# Altium schematic sheet STEREO_CAMERA_SHIELD_S01.SchDoc(STEREO_CAMERA_SHIELD_S01)
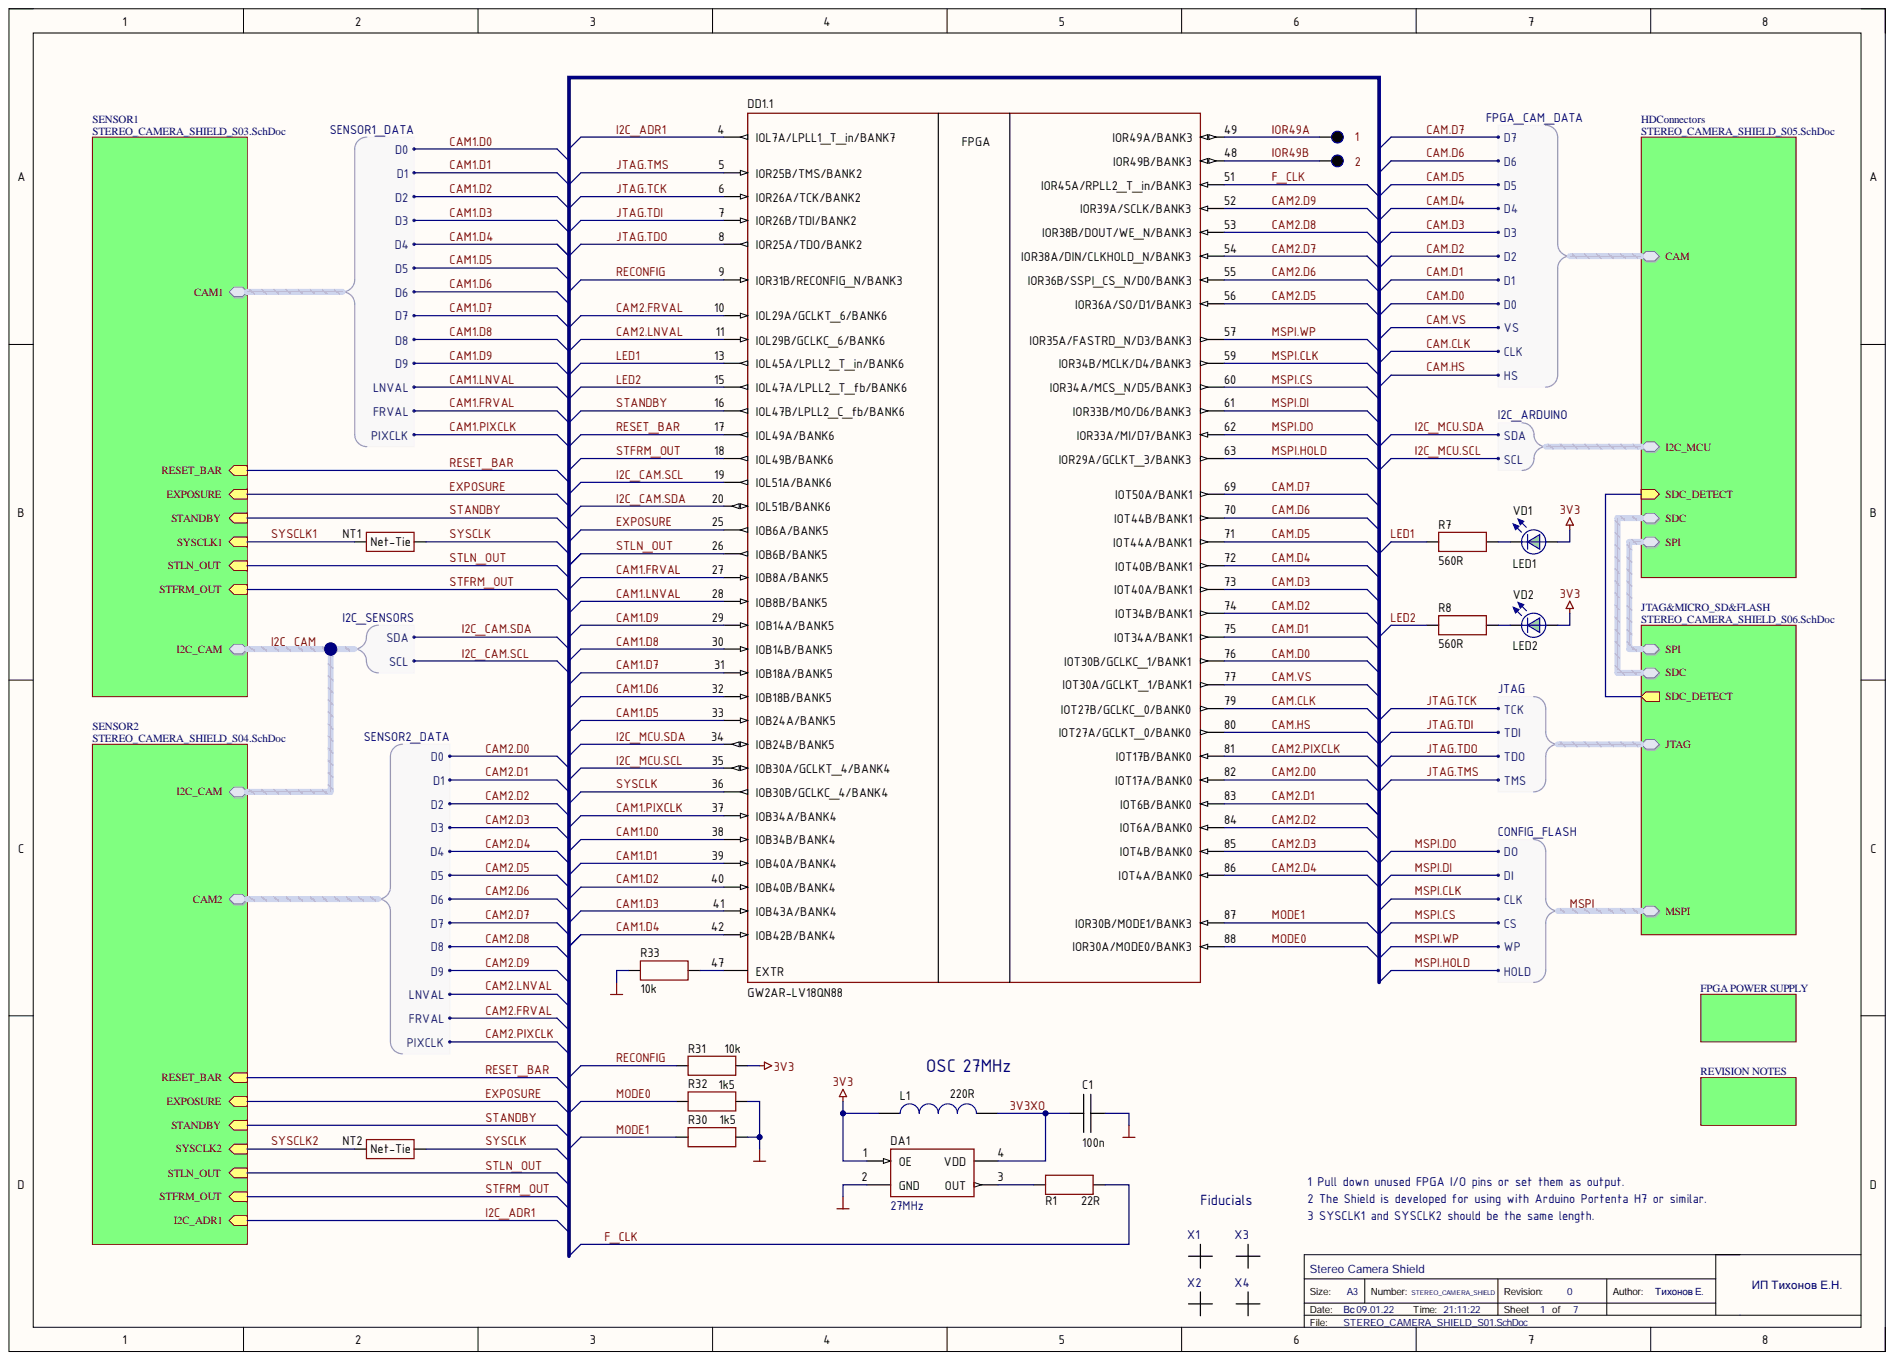
[diagram p0: STEREO_CAMERA_SHIELD_S01.SchDoc]

## Parts
1  test  [TEST_point_SMD_nosilk]  (value not drawn)  (no BOM)
2  test  [TEST_point_SMD_nosilk]  (value not drawn)  (no BOM)
C1  value 100n  [CAP: 0402]  (hidden comment: CER CAP 0402 100n 16V X7R ±5%)
DA1  Frequency: 27MHz  [OSC: 2520]  (hidden comment: XO (Standard), 27MHz, 50ppm, 2520 SMD)
DD1  ComponentType: FPGA  [88-QFN-TEP]  (hidden comment: FPGA, Arora GW2AR, , 1820,736 LUTs, 64M bits RAM, 1.0V, -40...+100°C, QFN88, 0.4mm)  (part .1)
L1  value 220R  [IND: 0603]  (hidden comment: FERRITE BEAD, 220R, , 0603, 500mA, -55...+125°C)
NT1  (no value)  [NetTie_2x0.15mm]
NT2  (no value)  [NetTie_2x0.15mm]
R1  value 22R  [RES: 0402]  (hidden comment: RES SMD 0402 22R ±5%)
R7  value 560R  [RES: 0402]  (hidden comment: RES SMD 0402 560R ±5%)
R8  value 560R  [RES: 0402]  (hidden comment: RES SMD 0402 560R ±5%)
R30  value 1k5  [RES: 0402]  (hidden comment: RES SMD 0402 1k5 ±5%)
R31  value 10k  [RES: 0402]  (hidden comment: RES SMD 0402 10k ±5%)
R32  value 1k5  [RES: 0402]  (hidden comment: RES SMD 0402 1k5 ±5%)
R33  value 10k  [RES: 0402]  (hidden comment: RES SMD 0402 10k ±5%)
VD1  ShowComment: LED1  [LED: 0603G]  (hidden comment: LED, 0603, GREEN)
VD2  ShowComment: LED2  [LED: 0603G]  (hidden comment: LED, 0603, GREEN)
X1  реперная метка для установщика  [REPER]  (value not drawn)  (no BOM)
X2  реперная метка для установщика  [REPER]  (value not drawn)  (no BOM)
X3  реперная метка для установщика  [REPER]  (value not drawn)  (no BOM)
X4  реперная метка для установщика  [REPER]  (value not drawn)  (no BOM)

## Nets
3V3: DA1.1(OE) L1.2 R31.2 VD1.1 VD2.1
GND: C1.1 DA1.2(GND) R30.1 R32.1 R33.1
3V3XO: C1.2 DA1.4(VDD) L1.1
CAM1.D0: DD1.38(IOB34B/BANK4)
CAM1.D1: DD1.39(IOB40A/BANK4)
CAM1.D2: DD1.40(IOB40B/BANK4)
CAM1.D3: DD1.41(IOB43A/BANK4)
CAM1.D4: DD1.42(IOB42B/BANK4)
CAM1.D5: DD1.33(IOB24A/BANK5)
CAM1.D6: DD1.32(IOB18B/BANK5)
CAM1.D7: DD1.31(IOB18A/BANK5)
CAM1.D8: DD1.30(IOB14B/BANK5)
CAM1.D9: DD1.29(IOB14A/BANK5)
CAM1.FRVAL: DD1.27(IOB8A/BANK5)
CAM1.LNVAL: DD1.28(IOB8B/BANK5)
CAM1.PIXCLK: DD1.37(IOB34A/BANK4)
CAM2.D0: DD1.82(IOT17A/BANK0)
CAM2.D1: DD1.83(IOT6B/BANK0)
CAM2.D2: DD1.84(IOT6A/BANK0)
CAM2.D3: DD1.85(IOT4B/BANK0)
CAM2.D4: DD1.86(IOT4A/BANK0)
CAM2.D5: DD1.56(IOR36A/SO/D1/BANK3)
CAM2.D6: DD1.55(IOR36B/SSPI_CS_N/D0/BANK3)
CAM2.D7: DD1.54(IOR38A/DIN/CLKHOLD_N/BANK3)
CAM2.D8: DD1.53(IOR38B/DOUT/WE_N/BANK3)
CAM2.D9: DD1.52(IOR39A/SCLK/BANK3)
CAM2.FRVAL: DD1.10(IOL29A/GCLKT_6/BANK6)
CAM2.LNVAL: DD1.11(IOL29B/GCLKC_6/BANK6)
CAM2.PIXCLK: DD1.81(IOT17B/BANK0)
CAM.CLK: DD1.79(IOT27B/GCLKC_0/BANK0)
CAM.D0: DD1.76(IOT30B/GCLKC_1/BANK1)
CAM.D1: DD1.75(IOT34A/BANK1)
CAM.D2: DD1.74(IOT34B/BANK1)
CAM.D3: DD1.73(IOT40A/BANK1)
CAM.D4: DD1.72(IOT40B/BANK1)
CAM.D5: DD1.71(IOT44A/BANK1)
CAM.D6: DD1.70(IOT44B/BANK1)
CAM.D7: DD1.69(IOT50A/BANK1)
CAM.HS: DD1.80(IOT27A/GCLKT_0/BANK0)
CAM.VS: DD1.77(IOT30A/GCLKT_1/BANK1)
EXPOSURE: DD1.25(IOB6A/BANK5)
F_CLK: DD1.51(IOR45A/RPLL2_T_in/BANK3) R1.2
I2C_ADR1: DD1.4(IOL7A/LPLL1_T_in/BANK7)
I2C_CAM.SCL: DD1.19(IOL51A/BANK6)
I2C_CAM.SDA: DD1.20(IOL51B/BANK6)
I2C_MCU.SCL: DD1.35(IOB30A/GCLKT_4/BANK4)
I2C_MCU.SDA: DD1.34(IOB24B/BANK5)
IOR49A: 1.1 DD1.49(IOR49A/BANK3)
IOR49B: 2.1 DD1.48(IOR49B/BANK3)
JTAG.TCK: DD1.6(IOR26A/TCK/BANK2)
JTAG.TDI: DD1.7(IOR26B/TDI/BANK2)
JTAG.TDO: DD1.8(IOR25A/TDO/BANK2)
JTAG.TMS: DD1.5(IOR25B/TMS/BANK2)
LED1: DD1.13(IOL45A/LPLL2_T_in/BANK6) R7.2
LED2: DD1.15(IOL47A/LPLL2_T_fb/BANK6) R8.2
MODE0: DD1.88(IOR30A/MODE0/BANK3) R32.2
MODE1: DD1.87(IOR30B/MODE1/BANK3) R30.2
MSPI.CLK: DD1.59(IOR34B/MCLK/D4/BANK3)
MSPI.CS: DD1.60(IOR34A/MCS_N/D5/BANK3)
MSPI.DI: DD1.61(IOR33B/MO/D6/BANK3)
MSPI.DO: DD1.62(IOR33A/MI/D7/BANK3)
MSPI.HOLD: DD1.63(IOR29A/GCLKT_3/BANK3)
MSPI.WP: DD1.57(IOR35A/FASTRD_N/D3/BANK3)
RECONFIG: DD1.9(IOR31B/RECONFIG_N/BANK3) R31.1
RESET_BAR: DD1.17(IOL49A/BANK6)
STANDBY: DD1.16(IOL47B/LPLL2_C_fb/BANK6)
STFRM_OUT: DD1.18(IOL49B/BANK6)
STLN_OUT: DD1.26(IOB6B/BANK5)
SYSCLK: DD1.36(IOB30B/GCLKC_4/BANK4) NT1.1 NT2.1
SYSCLK1: NT1.2
SYSCLK2: NT2.2
NetDA1_3: DA1.3(OUT) R1.1
NetDD1_47: DD1.47(EXTR) R33.2
NetR7_1: R7.1 VD1.2
NetR8_1: R8.1 VD2.2
SDC.CLK:
SDC.CMD:
SDC.D0:
SDC.D1:
SDC.D2:
SDC.D3:
SDC_DETECT:
SPI.CK:
SPI.CS:
SPI.MISO:
SPI.MOSI:

## Library data of the parts
1 2 = TEST point: Контактная площадка без компонента; Library Name: Connector.SchLib
C1 = Ceramic_0402: Manufacturer: Yageo; PartNumber: CC0402JRX7R7BB104; TypeCapacitor: CER CAP; Component Kind: Standard; Library Name: Capacitor.SchLib; Package: 0402; Temperature: -55...+125°C; Tolerance: ±5%; TypeDielectric: X7R; ValueManufacturerPartNumber: CER CAP 0402 100n 16V X7R ±5%; Voltage: 16V
DA1 = XO_2520: 27 MHz XO (Standard) CMOS Oscillator 3.3V Enable/Disable 4-SMD, No Lead; Manufacturer: Abracon LLC; PartNumber: ASDAIG-27.000MHZ-C-S-T; Component Kind: Standard; ComponentType: XO (Standard); HelpURL: https://www.digikey.com/en/products/detail/abracon-llc/ASDAIG-27-000MHZ-C-S-T/11476999; Library Name: Oscilator.SchLib; Package: 2520 SMD; Supply: 3.3V; Temperature: -40...+125°C; Tolerance: 50ppm; ValueManufacturerPartNumber: XO (Standard), 27MHz, 50ppm, 2520 SMD
DD1 = GW2AR-LV18QN88: FPGA Arora GW2AR; Manufacturer: Gowin; Component Kind: Standard; HelpURL: https://www.gowinsemi.com/en/product/detail/1/; Library Name: IC. FPGA.SchLib; LogicElements: 1820,736 LUTs; MemoryRAM: 64M bits RAM; Package: QFN88, 0.4mm; PartNumber: GW2AR-LV18QN88; Series: Arora GW2AR; Supply: 1.0V; Temperature: -40...+100°C; ValueManufacturerPartNumber: FPGA, Arora GW2AR, , 1820,736 LUTs, 64M bits RAM, 1.0V, -40...+100°C, QFN88, 0.4mm
L1 = EMI_SMD: Manufacturer: Murata Electronics; PartNumber: BLM18AG221SN1D; Component Kind: Standard; HelpURL: https://www.digikey.com/en/products/detail/murata-electronics/BLM18AG221SN1D/416362?s=N4IgTCBcDaIEIBkCyBGAHAQQOJjCgygHIoAiIAugL5A; Library Name: Inductor.SchLib; MaxCurrent: 500mA; Package: 0603; Temperature: -55...+125°C; TypeInductor: FERRITE BEAD; ValueManufacturerPartNumber: FERRITE BEAD, 220R, , 0603, 500mA, -55...+125°C
NT1 NT2 = Net-Tie: ClassName: Polygon_direct; Component Kind: Net Tie; Library Name: PCB.SchLib; Manufacturer: N/A; Package: 0603; TypeResistor: Net-Tie
R1 = Resistor_0402: Manufacturer: Yageo; PartNumber: RC0402JR-0722RL; Power: 0.063W; TypeResistor: RES SMD; Component Kind: Standard; Library Name: Resistor.SchLib; Package: 0402; Temperature: -55...+125°C; Tolerance: ±5%; ValueManufacturerPartNumber: RES SMD 0402 22R ±5%
R7 R8 = Resistor_0402: Manufacturer: Yageo; PartNumber: RC0402JR-07560RL; Power: 0.063W; TypeResistor: RES SMD; Component Kind: Standard; Library Name: Resistor.SchLib; Package: 0402; Temperature: -55...+125°C; Tolerance: ±5%; ValueManufacturerPartNumber: RES SMD 0402 560R ±5%
R30 R32 = Resistor_0402: Manufacturer: Yageo; PartNumber: RC0402JR-071K5L; Power: 0.063W; TypeResistor: RES SMD; Component Kind: Standard; Library Name: Resistor.SchLib; Package: 0402; Temperature: -55...+125°C; Tolerance: ±5%; ValueManufacturerPartNumber: RES SMD 0402 1k5 ±5%
R31 R33 = Resistor_0402: Manufacturer: Yageo; PartNumber: RC0402JR-0710KL; Power: 0.063W; TypeResistor: RES SMD; Component Kind: Standard; Library Name: Resistor.SchLib; Package: 0402; Temperature: -55...+125°C; Tolerance: ±5%; ValueManufacturerPartNumber: RES SMD 0402 10k ±5%
VD1 VD2 = KP-1608SGC: LED green 0603; Manufacturer: Kingbright; Current: 20mA; VoltageDrop: 2V; Color: GREEN; Component Kind: Standard; Library Name: Diode.SchLib; Package: 0603; PartNumber: KP-1608SGC; Temperature: -55...+150°C; TypeDiode: LED; ValueManufacturerPartNumber: LED, 0603, GREEN
X1-X4 = REPER: реперная метка; Library Name: PCB.SchLib; Package: SMD; ValueManufacturerPartNumber: реперная метка для установщика
